# Altium schematic sheet Client_Node.SchDoc
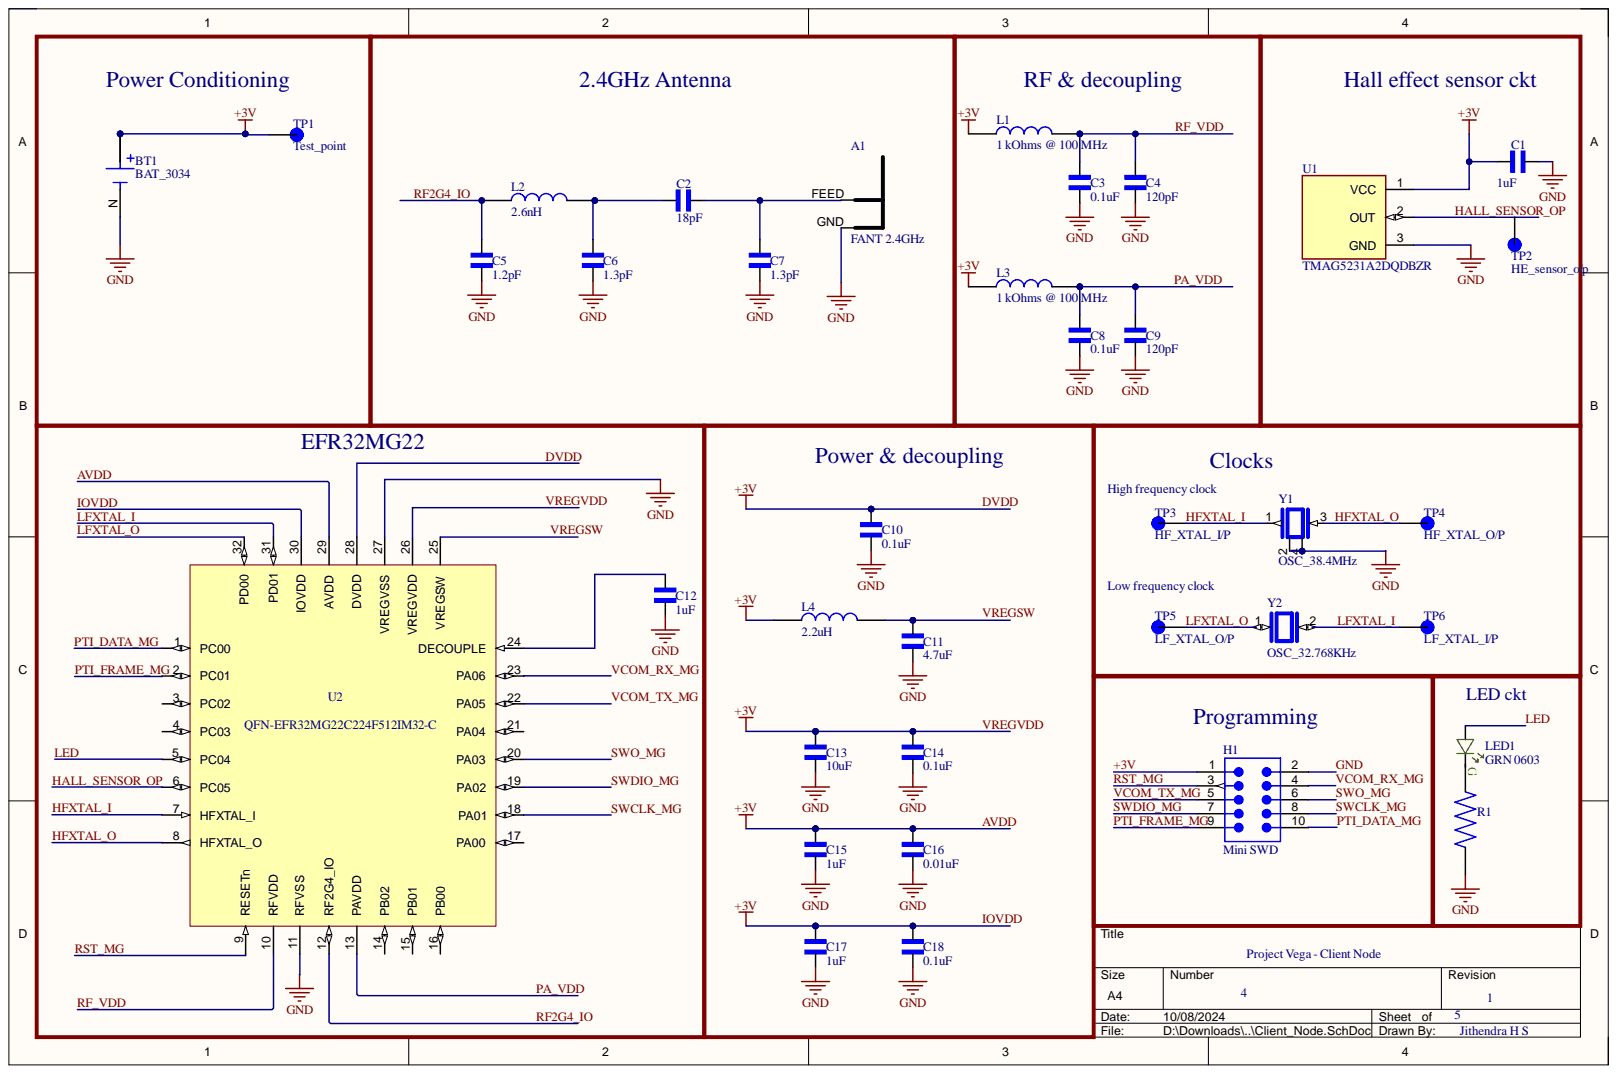
[diagram p0: Client_Node.SchDoc]

## Parts
A1  FANT 2.4GHz  [FANT_2P4]
BT1  BAT_3034  [BAT_3034]
C1  1uF  [CAPC1608X90]
C2  18pF  [CAPC1608X90]
C3  0.1uF  [CAPC1608X90]
C4  120pF  [CAPC1608X90]
C5  1.2pF  [CAPC1608X90]
C6  1.3pF  [CAPC1608X90]
C7  1.3pF  [CAPC1608X90]
C8  0.1uF  [CAPC1608X90]
C9  120pF  [CAPC1608X90]
C10  0.1uF  [CAPC1608X90]
C11  4.7uF  [CAPC1608X90]
C12  1uF  [CAPC1608X90]
C13  10uF  [CAPC1608X90]
C14  0.1uF  [CAPC1608X90]
C15  1uF  [CAPC1608X90]
C16  0.01uF  [CAPC1608X90]
C17  1uF  [CAPC1608X90]
C18  0.1uF  [CAPC1608X90]
H1  Mini SWD  [FTSH-105-01-XXX-DV-K]
L1  1 kOhms @ 100 MHz  [INDC1608X95N]
L2  2.6nH  [INDC1608X95N]
L3  1 kOhms @ 100 MHz  [INDC1608X95N]
L4  2.2uH  [INDC1608X95N]
LED1  GRN 0603  [LED_0603_GRN_WL-SMCW]
R1  RES_0603  [RES_0603]  (value not drawn)
TP1  Test_point  [TP]
TP2  HE_sensor_o/p  [TP]
TP3  HF_XTAL_I/P  [TP]
TP4  HF_XTAL_O/P  [TP]
TP5  LF_XTAL_O/P  [TP]
TP6  LF_XTAL_I/P  [TP]
U1  TMAG5231A2DQDBZR  [SOT95P237X112-3N]
U2  QFN-EFR32MG22C224F512IM32-C  [QFN32-EFR32xG2xIM32-BR]
Y1  OSC_38.4MHz  [OSC_38.4MHz]
Y2  OSC_32.768KHz  [OSC_32.768KHz]

## Nets
+3V: BT1.P1 BT1.P2 C1.2 C10.1 C13.1 C14.1 C15.1 C16.1 C17.1 C18.1 H1.1 L1.1 L3.1 L4.1 TP1.TP U1.1 U2.26(VREGVDD) U2.28(DVDD) U2.29(AVDD) U2.30(IOVDD)
GND: A1.GND BT1.N C1.1 C3.2 C4.2 C5.2 C6.2 C7.2 C8.2 C9.2 C10.2 C11.2 C12.2 C13.2 C14.2 C15.2 C16.2 C17.2 C18.2 H1.2 R1.2 U1.3 U2.11(RFVSS) U2.27(VREGVSS) Y1.2 Y1.4
HALL_SENSOR_OP: U1.2 U2.6(PC05)
HFXTAL_I: TP3.TP U2.7(HFXTAL_I) Y1.1
HFXTAL_O: TP4.TP U2.8(HFXTAL_O) Y1.3
LED: LED1.A U2.5(PC04)
LFXTAL_I: TP6.TP U2.31(PD01) Y2.2
LFXTAL_O: TP5.TP U2.32(PD00) Y2.1
PA_VDD: C8.1 C9.1 L3.2 U2.13(PAVDD)
PTI_DATA_MG: H1.10 U2.1(PC00)
PTI_FRAME_MG: H1.9 U2.2(PC01)
RF2G4_IO: C5.1 L2.1 U2.12(RF2G4_IO)
RF_VDD: C3.1 C4.1 L1.2 U2.10(RFVDD)
RST_MG: H1.3 U2.9(RESETn)
SWCLK_MG: H1.8 U2.18(PA01)
SWDIO_MG: H1.7 U2.19(PA02)
SWO_MG: H1.6 U2.20(PA03)
VCOM_RX_MG: H1.4 U2.23(PA06)
VCOM_TX_MG: H1.5 U2.22(PA05)
VREGSW: C11.1 L4.2 U2.25(VREGSW)
NetA1_FEED: A1.FEED C2.2 C7.1
NetC2_1: C2.1 C6.1 L2.2
NetC12_1: C12.1 U2.24(DECOUPLE)

Not connected: LED1 C; R1 1; TP2 TP; U2 3(PC02) 4(PC03) 14(PB02) 15(PB01) 16(PB00) 17(PA00) 21(PA04)

## Library data of the parts
A1 = FANT_2P4: Inverted F antenna; Component Kind: Standard; Library Name: Project_Vega.SchLib
BT1 = BAT_3034: SMT Holder for 20mm Cell-Tin Nickel Plate; MF: Keystone Electronics Corp.; MP: 3034; Availability: Not in stock; Check_prices: https://www.snapeda.com/parts/3034/Keystone+Electronics/view-part/?ref=eda; Component Kind: Standard; Package: None; Price: None; SnapEDA_Link: https://www.snapeda.com/parts/3034/Keystone+Electronics/view-part/?ref=snap; Supplier 1: Digikey; Supplier Part Number 1: 36-3034-ND; Library Name: Project_Vega.IntLib
C1-C18 = CC0603KRX7R9BB104: 0.1 ÂµF Â±10% 50V Ceramic Capacitor X7R 0603 (1608 Metric); MF: Yageo; Check_prices: https://www.snapeda.com/parts/CC0603KRX7R9BB104/Yageo/view-part/?ref=eda; Component Kind: Standard; MP: CC0603KRX7R9BB104; Package: 0603 Yageo; SnapEDA_Link: https://www.snapeda.com/parts/CC0603KRX7R9BB104/Yageo/view-part/?ref=snap; Library Name: Project_Vega.IntLib
H1 = Debug Connector Mini SWD - 0.05": Mini SWD 0.05" Pitch Connector - 10 Pin SMT Box Header; Manufacturer: Adafruit Industries; Manufacturer Part Number: 4048; Category: Connectors; Component Kind: Standard; ComponentLink1Description: https://media.digikey.com/pdf/Data%20Sheets/Adafruit%20PDFs/4048_Web.pdf?src-supplier=Digi-Key; ComponentLink1URL: https://media.digikey.com/pdf/Data%20Sheets/Adafruit%20PDFs/4048_Web.pdf?src-supplier=Digi-Key; Library Name: Connectors.IntLib; Pricing 1: 1=1.95000 (USD); RoHS: No; Stock 1: 201; Supplier 1: Digikey; Supplier Part Number 1: 1528-2827-ND
L1-L4 = LQM18DN100M70L: Inductor with Inductance 10uH Tol.+/-20% Package 0603 (1608); MF: Murata Electronics; Availability: Unavailable; Component Kind: Standard; MP: LQM18DN100M70L; Package: 0603 Murata; Price: None; SnapEDA_Link: https://www.snapeda.com/parts/LQM18DN100M70L/Murata/view-part/4834755/?ref=snap; Library Name: Project_Vega.IntLib
LED1 = LED_green: LED, 0603, GREEN, 430MCD, 520NM; Manufacturer: Wurth Electronics; Manufacturer Part Number: 150060GS75000; 3D Model: SMCW_0603.igs    SMCW_0603.stp    SMCW_0603.wrl; Current - Test: 20mA; Dynamic Catalog: 0603 Rectangle with Flat Top Chip LEDs; Lens Style/Size: Rectangle with Flat Top, 1.1mm x 0.7mm; Lens Type: Clear; Other Names: 732-4971-2; Viewing Angle: 140°; Voltage - Forward (Vf) (Typ): 3.2V; Wavelength - Peak: 515nm; Category: Optoelectronics; Color: Green; Component Kind: Standard; ComponentLink1Description: https://katalog.we-online.de/led/datasheet/150060GS75000.pdf?src-supplier=Digi-Key; ComponentLink1URL: https://katalog.we-online.de/led/datasheet/150060GS75000.pdf?src-supplier=Digi-Key; ComponentLink2Description: http://www.produktinfo.conrad.com/datenblaetter/750000-774999/759427-da-01-en-CHIPLED_0603_W_KL_GRUEN_150060GS75000.pdf?src-supplier=Conrad; ComponentLink2URL: http://www.produktinfo.conrad.com/datenblaetter/750000-774999/759427-da-01-en-CHIPLED_0603_W_KL_GRUEN_150060GS75000.pdf?src-supplier=Conrad; Family: LED Indication - Discrete; Height: 0.70mm; Millicandela Rating: 430mcd; Mounting Type: Surface Mount; Online Catalog: 0603 Rectangle with Flat Top Chip LEDs; Package / Case: 0603 (1608 Metric); Packaging: Tape & Reel (TR); Pricing 1: 1=0.14, 50=0.129, 100=0.11, 500=0.095 (USD); Series: WL-SMCW; Size / Dimension: 1.60mm L x 0.80mm W; Standard Package: 4,000; Stock 1: 34964; Supplier 1: Digi-Key; Supplier Part Number 1: 732-4971-1-ND; Wavelength - Dominant: 520nm; Library Name: Project_Vega.IntLib
R1 = RES_0603: Thick film general purpose resistor 0603; MP: RC0603JR-070RL; Availability: Unavailable; Component Kind: Standard; Package: None; Price: None; Library Name: Project_Vega.IntLib
TP1-TP6 = Test_point: SMT Test Point- Mininature-1000 pc Reels; MF: Keystone Electronics; MP: 5019; Availability: In Stock; Component Kind: Standard; Package: None; Price: None; Purchase-URL: https://pricing.snapeda.com/search/part/5019/?ref=eda; Library Name: Project_Vega.IntLib
U1 = TMAG5231A2DQDBZR: SOT-23-3-Digital Switch Omnipolar Switch Push-Pull Hall Effect; MF: {'manufacturer': u'Texas Instruments'}; Availability: Unavailable; Component Kind: Standard; MP: TMAG5231A2DQDBZR; Package: None; Price: None; Supplier 1: Digikey; Supplier Part Number 1: 296-TMAG5231A2DQDBZRCT-ND; Library Name: Project_Vega.SchLib
U2 = EFR32MG22C224F512IM32-C: IC RF TxRx + MCU WiFi Bluetooth v5.2, Zigbee® 2.4GHz 32-VFQFN Exposed Pad; Component Kind: Standard; Library Name: Project_Vega.IntLib
Y1 = OSC_38.4MHz: 38.4 MHz ±10ppm Crystal 10pF 40 Ohms 4-SMD, No Lead; MF: {'manufacturer': u'Murata Electronics'}; MP: XRCGB38M400F2P00R0; Availability: Unavailable; Component Kind: Standard; Package: None; Price: None; Supplier 1: Digikey; Supplier Part Number 1: 4606-TZ3398BCT-ND; Library Name: Project_Vega.IntLib
Y2 = OSC_32.768KHz: Tuning Fork Crystal 32.768kHz in 1.2 x 2.0mm package; MF: Micro Crystal; MP: CM8V-T1A-32.768KHZ-7PF-20PPM-TA-QC; Availability: Not in stock; Check_prices: https://www.snapeda.com/parts/CM8V-T1A-32.768KHZ-7PF-20PPM-TA-QC/Micro+Crystal/view-part/?ref=eda; Component Kind: Standard; Package: SMD-2 Micro Crystal; Price: None; SnapEDA_Link: https://www.snapeda.com/parts/CM8V-T1A-32.768KHZ-7PF-20PPM-TA-QC/Micro+Crystal/view-part/?ref=snap; Supplier 1: Digikey; Supplier Part Number 1: 2195-CM8V-T1A-32.768KHZ-7PF-20PPM-TA-QCCT-ND; Library Name: Project_Vega.IntLib
